# Altium schematic sheet analog_CH1.SchDoc
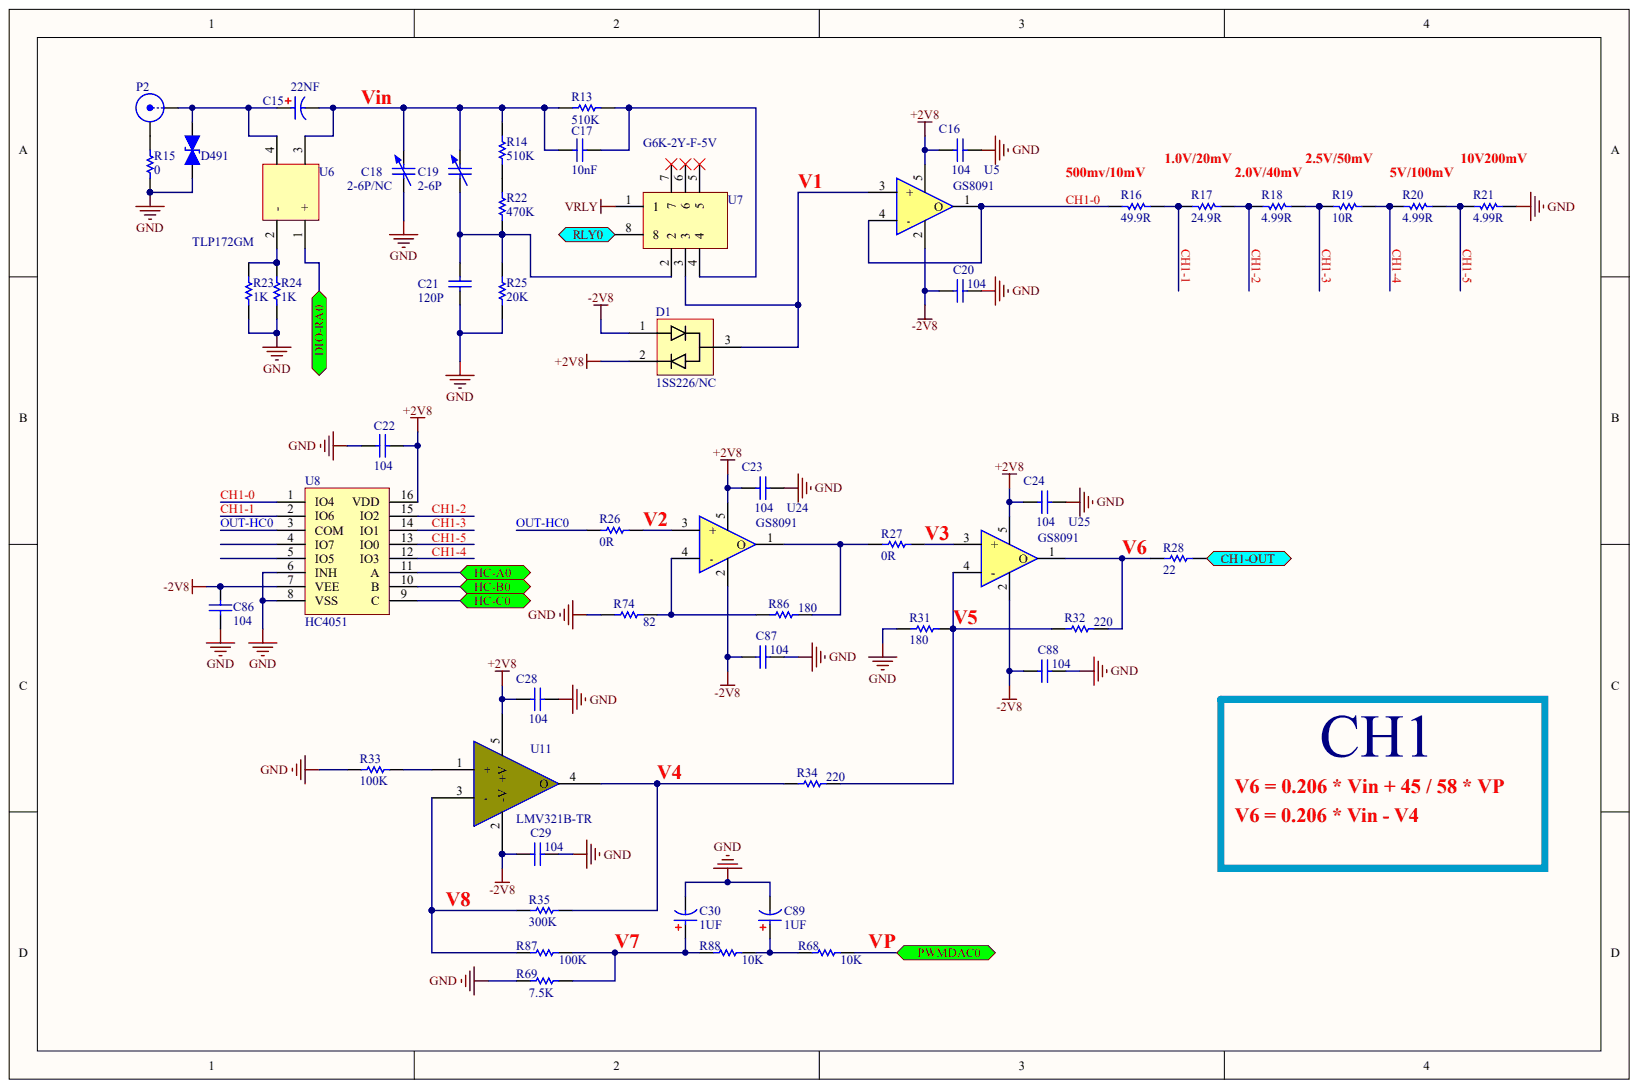
[diagram p0: analog_CH1.SchDoc]

## Parts
C15  22NF
C16  C104  (value not drawn)
C17  10nF
C18  value 2-6P/NC  (hidden comment: V_CAP/NC)
C19  value 2-6P  (hidden comment: V_CAP)
C20  C104  (value not drawn)
C21  120P
C22  C104  (value not drawn)
C23  C104  (value not drawn)
C24  C104  (value not drawn)
C28  C104  (value not drawn)
C29  C104  (value not drawn)
C30  1UF
C86  C104  (value not drawn)
C87  C104  (value not drawn)
C88  C104  (value not drawn)
C89  1UF
D1  1SS226/NC
D491  ESD_0402  (value not drawn)
P2  MCX  (value not drawn)
R13  510K
R14  510K
R15  0
R16  49.9R
R17  24.9R
R18  4.99R
R19  10R
R20  4.99R
R21  4.99R
R22  470K
R23  1K
R24  1K
R25  20K
R26  0R
R27  0R
R28  22
R31  180
R32  220
R33  100K
R34  220
R35  300K
R68  10K
R69  7.5K
R74  82
R86  180
R87  100K
R88  10K
U5  GS8091
U6  TLP172GM
U7  G6K-2Y-F-5V
U8  HC4051
U11  LMV321B-TR
U24  GS8091
U25  GS8091

## Ports
CH1-OUT, DIO-RA0, HC-A0, HC-B0, HC-C0, PWMDAC0, RLY0

## Nets
+2V8: C16.1 C22.2 C23.1 C24.1 C28.1 D1.2 U5.5 U8.16(VDD) U11.5(+V) U24.5 U25.5
-2V8: C20.1 C29.1 C86.1 C87.1 C88.1 D1.1 U5.2 U8.7(VEE) U11.2(-V) U24.2 U25.2
GND: C16.2 C18.1 C20.2 C21.2 C22.1 C23.2 C24.2 C28.2 C29.2 C30.2 C86.2 C87.2 C88.2 C89.2 D491.2 R15.2 R21.1 R23.1 R24.1 R25.2 R31.1 R33.1 R69.1 R74.1 U8.6(INH) U8.8(VSS)
VRLY: U7.1
CH1-0: R16.2 U5.1(O) U5.4(-) U8.1(IO4)
CH1-1: R16.1 R17.2 U8.2(IO6)
CH1-2: R17.1 R18.2 U8.15(IO2)
CH1-3: R18.1 R19.2 U8.14(IO1)
CH1-4: R19.1 R20.2 U8.12(IO3)
CH1-5: R20.1 R21.2 U8.13(IO0)
CH1-OUT: R28.2 ; port CH1-OUT
DIO-RA0: U6.1(+) ; port DIO-RA0
HC-A0: U8.11(A) ; port HC-A0
HC-B0: U8.10(B) ; port HC-B0
HC-C0: U8.9(C) ; port HC-C0
OUT-HC0: R26.1 U8.3(COM)
PWMDAC0: R68.2 ; port PWMDAC0
RLY0: U7.8 ; port RLY0
NetC15_1: C15.1 D491.1 P2.1 U6.4
NetC15_2: C15.2 C17.1 C18.2 C19.2 R13.1 R14.1 U6.3
NetC17_2: C17.2 R13.2 U7.4
NetC19_1: C19.1 C21.1 R22.2 R25.1 U7.2
NetC30_1: C30.1 R69.2 R87.2 R88.1
NetC89_1: C89.1 R68.1 R88.2
NetD1_3: D1.3 U5.3(+) U7.3
NetP2_2: P2.2 R15.1
NetR14_2: R14.2 R22.1
NetR23_2: R23.2 R24.2 U6.2(-)
NetR26_2: R26.2 U24.3(+)
NetR27_1: R27.1 R86.2 U24.1(O)
NetR27_2: R27.2 U25.3(+)
NetR28_1: R28.1 R32.2 U25.1(O)
NetR31_2: R31.2 R32.1 R34.2 U25.4(-)
NetR33_2: R33.2 U11.1(+)
NetR34_1: R34.1 R35.2 U11.4(O)
NetR35_1: R35.1 R87.1 U11.3(-)
NetR74_2: R74.2 R86.1 U24.4(-)

Not connected: U7 5 6 7; U8 4(IO7) 5(IO5)

## Library data of the parts
C15-C24 C28-C30 C86-C89 D1 D491 P2 R13-R28 R31-R35 R68 R69 R74 R86-R88 U5-U8 U11 U24 U25 = (no symbol name)
  C15: : 22NF
  C16 C20 C22-C24 C28 C29 C86-C88: : 104
  C17: : 10nF
  C18 C19: Published: 24-Mar-1999; LatestRevisionDate: 29-May-2009; LatestRevisionNote: IPC-7351 Footprint Added.; PackageReference: C1210; PackageDescription: Chip Capacitor 1210 Case Size; Code_JEITA: 1210; Code_IPC: CAPC3225
  C21: : 120P
  C30 C89: : 1UF
  P2: LatestRevisionDate: 17-Jul-2002; LatestRevisionNote: Re-released for DXP Platform.
  R13 R14: : 510K
  R15: : 0
  R16: : 49.9R
  R17: : 24.9R
  R18 R20 R21: : 4.99R
  R19: : 10R
  R22: : 470K
  R23 R24: : 1K
  R25: : 20K
  R26 R27: : 0R
  R28: : 22
  R31 R86: : 180
  R32 R34: : 220
  R33 R87: : 100K
  R35: : 300K
  R68 R88: : 10K
  R69: : 7.5K
  R74: : 82
  C18 C19 P2: Publisher: Altium Limited
